# Altium schematic sheet CAN_Module.SchDoc
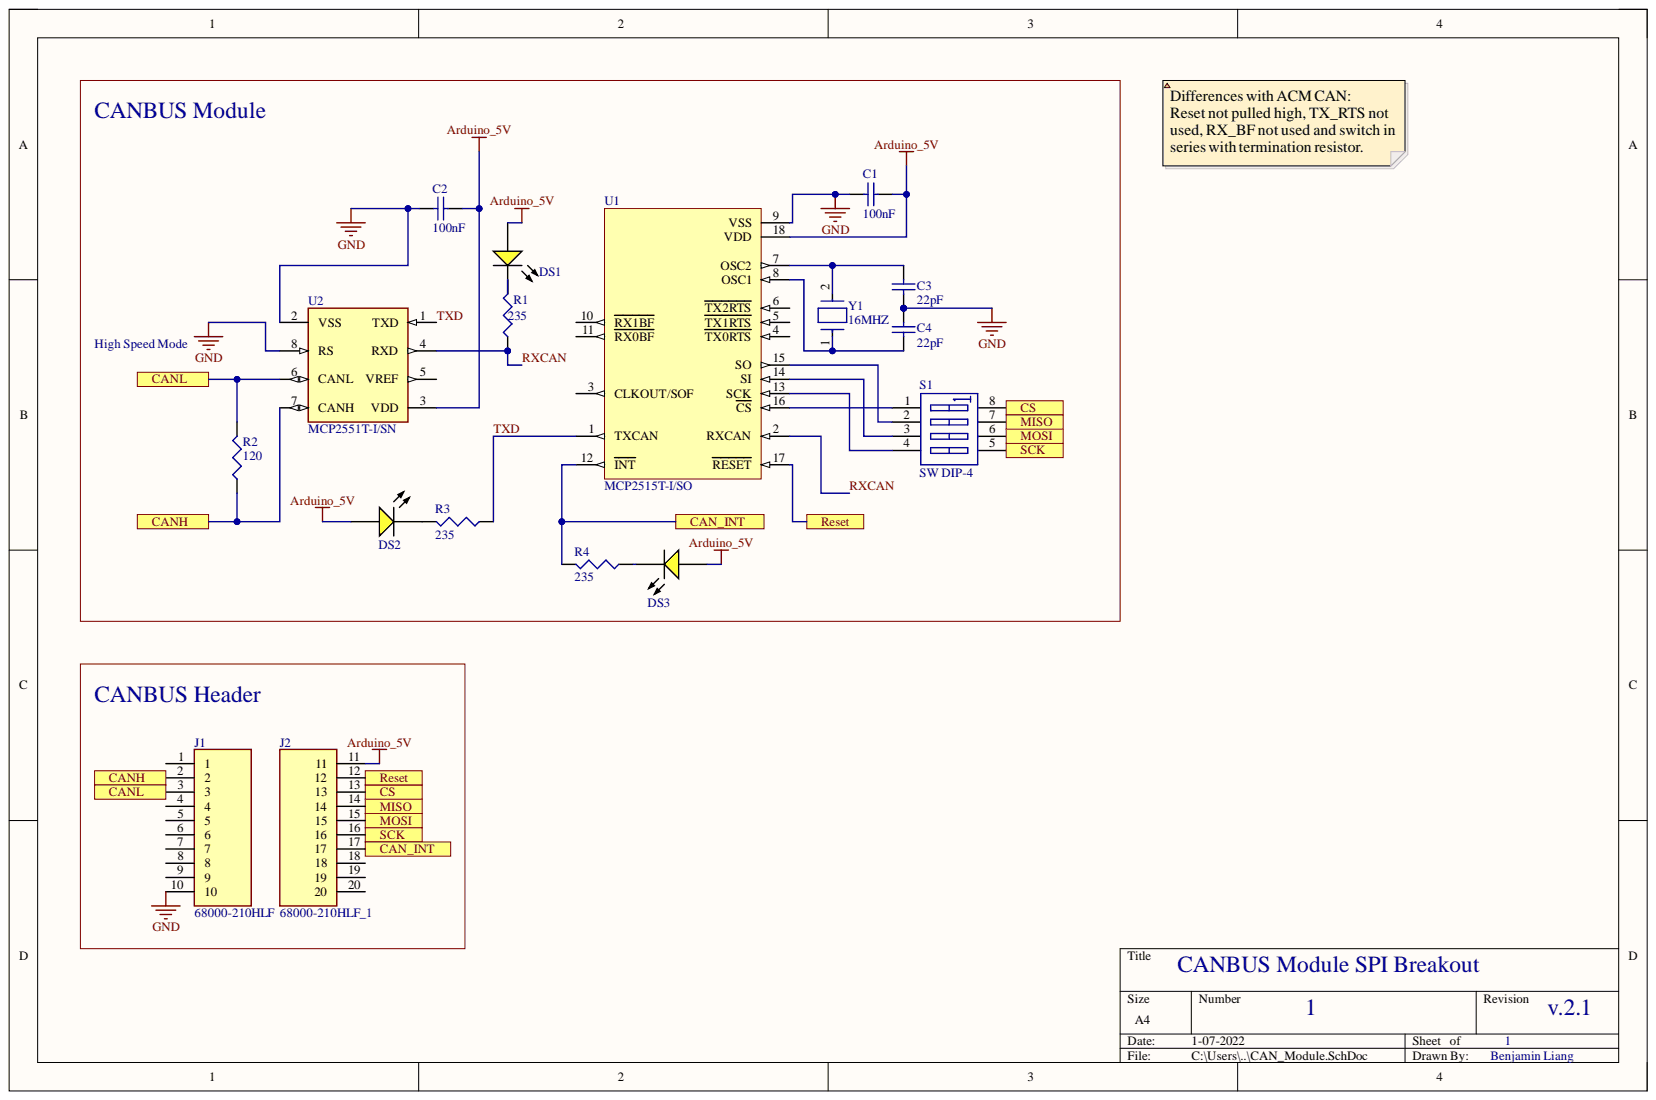
[diagram p0: CAN_Module.SchDoc]

## Parts
C1  100nF  [C1206]
C2  100nF  [C1206]
C3  22pF  [C1206]
C4  22pF  [C1206]
DS1  HLMP-1719  [AVAG-HLMP-1719_V]  (value not drawn)
DS2  HLMP-1719  [AVAG-HLMP-1719_V]  (value not drawn)
DS3  HLMP-1719  [AVAG-HLMP-1719_V]  (value not drawn)
J1  68000-210HLF  [HDRV10W63P0X254_1X10_2540X241X858P]
J2  68000-210HLF_1  Arrow Part Number: 68000-210HLF  [HDRV10W63P0X254_1X10_2540X241X858P]
R1  235  [J1-0603]
R2  120  [J1-0603]
R3  235  [J1-0603]
R4  235  [J1-0603]
S1  SW DIP-4  [SO8_N]
U1  MCP2515T-I/SO  [SOIC-SO18_N]
U2  MCP2551T-I/SN  [FP-C04-057-SN-IPC_B]
Y1  16MHZ  [FP-ABLS-MFG]

## Ports
CAN_INT, CANH, CANL, CS, MISO, MOSI, Reset, SCK

## Nets
Arduino_5V: C1.1 C2.1 DS1.1 DS2.1 DS3.1 J2.11 U1.18(VDD) U2.3(VDD)
GND: C1.2 C2.2 C3.1 C4.2 J1.10 U1.9(VSS) U2.2(VSS) U2.8(RS)
CAN_INT: J2.17 R4.2 U1.12(INT) ; port CAN_INT
CANH: J1.2 R2.1 U2.7(CANH) ; port CANH
CANL: J1.3 R2.2 U2.6(CANL) ; port CANL
CS: J2.13 S1.8 ; port CS
MISO: J2.14 S1.7 ; port MISO
MOSI: J2.15 S1.6 ; port MOSI
Reset: J2.12 U1.17(RESET) ; port Reset
RXCAN: R1.1 U1.2(RXCAN) U2.4(RXD)
SCK: J2.16 S1.5 ; port SCK
TXD: R3.1 U1.1(TXCAN) U2.1(TXD)
NetC3_2: C3.2 U1.7(OSC2) Y1.2
NetC4_1: C4.1 U1.8(OSC1) Y1.1
NetDS1_2: DS1.2 R1.2
NetDS2_2: DS2.2 R3.2
NetDS3_2: DS3.2 R4.1
NetS1_1: S1.1 U1.16(CS)
NetS1_2: S1.2 U1.15(SO)
NetS1_3: S1.3 U1.14(SI)
NetS1_4: S1.4 U1.13(SCK)

Not connected: J1 1 4 5 6 7 8 9; J2 18 19 20; U1 3(CLKOUT/SOF) 4(TX0RTS) 5(TX1RTS) 6(TX2RTS) 10(RX1BF) 11(RX0BF); U2 5(VREF)

## Library data of the parts
C1-C4 = Cap Semi: Capacitor (Semiconductor SIM Model); Component Kind: Standard; LatestRevisionDate: 29-May-2009; LatestRevisionNote: IPC-7351 Footprint Added.; Library Name: Miscellaneous Devices.IntLib; PackageDescription: Chip Capacitor; PackageReference: C1206; Published: 8-Jun-2000; Signal Integrity: Cap Semi; Simulation: CAP; Publisher: Altium Limited
  C1 C2: Value: 100nF
  C3 C4: Value: 22pF
DS1-DS3 = CMP-2000-06410-1: Low Current LED Lamp, T-1 (3 mm), 1.8 V, 2 mA, -40 to 100 degC, 2-Pin THD, RoHS, Bulk; Manufacturer: AvagoTechnologies; Color: Yellow; Dominant Wavelength/Color Temperature: 585nm; Intensity: 2.1mcd; Lens Appearance: ColoredDiffused; Lens Shape Type: Circular; Mounting Orientation: TopMount; Number of LEDs: 1; Type: Uni-Color; Viewing Angle: 50°; Category: LEDs; Component Kind: Standard; Datasheet URL: http://www.avagotech.com/docs/AV02-1557EN; Datasheet Version: 07/2014; Library Name: Altium Content Vault; Manufacturer URL: http://www.avagotech.com/; Mounting: ThroughHole; Mounting Technology: ThroughHole; Package: 2T-1; Package Description: 2-Pin Through Hole Device, Body Dia 3.175 mm; Package Reference: HLMP-1719; Packaging: Bulk; Pin Count: 2
J1 = 68000-210HLF: Connector; Manufacturer_Name: Amphenol; Arrow Part Number: 68000-210HLF; Arrow Price/Stock: https://www.arrow.com/en/products/68000-210hlf/amphenol-fci; Component Kind: Standard; Datasheet Link: https://cdn.amphenol-icc.com/media/wysiwyg/files/drawing/68000.pdf; Height: 8.58mm; Manufacturer_Part_Number: 68000-210HLF; Mouser Part Number: 649-68000-210HLF; Mouser Price/Stock: https://www.mouser.co.uk/ProductDetail/Amphenol-FCI/68000-210HLF?qs=QKvFUfBIyQINb7f%2F4SDc2A%3D%3D
J2 = 68000-210HLF_1: Connector; Manufacturer_Name: Amphenol; Arrow Price/Stock: https://www.arrow.com/en/products/68000-210hlf/amphenol-fci; Component Kind: Standard; Datasheet Link: https://cdn.amphenol-icc.com/media/wysiwyg/files/drawing/68000.pdf; Height: 8.58mm; Library Name: SamacSys.SchLib; Manufacturer_Part_Number: 68000-210HLF; Mouser Part Number: 649-68000-210HLF; Mouser Price/Stock: https://www.mouser.co.uk/ProductDetail/Amphenol-FCI/68000-210HLF?qs=QKvFUfBIyQINb7f%2F4SDc2A%3D%3D
R1-R4 = Res3: Resistor; Value: 220; Component Kind: Standard; LatestRevisionDate: 29-May-2009; LatestRevisionNote: IPC-7351 Footprint Added.; Library Name: Miscellaneous Devices.IntLib; PackageDescription: Chip Resistor; PackageReference: J1-0603; Published: 8-Jun-2000; Signal Integrity: Res; Simulation: RESISTOR; Publisher: Altium Limited
S1 = SW DIP-4: DIP Switch, 4 Position, SPST; Code_IPC: SOIC127P600-8; Code_JEDEC: MS-012-AA; Component Kind: Standard; LatestRevisionDate: 29-May-2009; LatestRevisionNote: IPC-7351 Footprint Added.; Library Name: Miscellaneous Devices.IntLib; PackageDescription: 8-Pin Small Outline Integrated Circuit 1.27 mm Pitch; PackageReference: SO8; PackageVersion: Dec-2002; Published: 24-Mar-1999; Simulation: dpsw4; Publisher: Altium Limited
U1 = CMP-0186-00139-2: Stand-Alone CAN Controller With SPI Interface, 18-Pin SOIC, Industrial Temperature, Tape and Reel; Code_JEDEC: MS-013; Component Kind: Standard; ComponentLink1Description: Manufacturer URL; ComponentLink1URL: http://www.microchip.com/; ComponentLink2Description: Datasheet; ComponentLink2URL: http://ww1.microchip.com/downloads/en/DeviceDoc/21801f.pdf; ComponentLink3Description: Package Specification; ComponentLink3URL: http://www.microchip.com/stellent/groups/techpub_sg/documents/packagingspec/en012702.pdf; DatasheetVersion: revF, Oct-2010; Library Name: Altium Content Vault; PackageDescription: 18-Lead Plastic Small Outline (SO) - Wide 7.50mm Body [SOIC]; PackageReference: SOIC-SO18; PackageVersion: revBB, Aug-2009; RevisionNote: URLs added. DatasheetVersion updated.
U2 = CMP-0186-00037-3: IC TRANSCEIVER HALF 1/1 8SOIC; Data Rate: 1Mbps; Interface: CAN; Max Supply Current: 75mA; Max Supply Voltage: 5.5V; Min Supply Voltage: 4.5V; Number of Drivers: 1; Operating Supply Voltage: 5V; Case/Package: SOIC; Component Kind: Standard; Height: 1.5mm; Lead Free: Lead Free; Length: 4.9mm; Library Name: Altium Content Vault; Logic Function: Transceiver; Max Operating Temperature: 85°C; Min Operating Temperature: -40°C; Mount: Surface Mount; Nominal Supply Current: 75mA; Number of Pins: 8; Number of Receive Buffers: 1; Number of Receivers: 1; Number of Transceivers: 1; Number of Transmit Buffers: 1; Package Quantity: 3300; Packaging: Tape and Reel; Radiation Hardening: No; REACH SVHC: No SVHC; Width: 3.9mm
Y1 = CMP-27762-000003-1: CRYSTAL 16.0000MHZ 18PF SMD  ABLS-16.000MHZ-K4T; Manufacturer: Abracon; Esr: 40Ω; Stability: 50ppm; Standoff Height: 0mm; Tolerance: 30ppm; Automotive: No; Category: Crys; Component Kind: Standard; ComponentLink2Description: Datasheet; ComponentLink2URL: https://abracon.com/Resonators/abls.pdf; Device Class L1: Passive Components; Device Class L2: Crystals / Oscillators; Device Class L3: Crystals; Digikey Description: CRYSTAL 16.0000MHZ 18PF SMD; Frequency: 16MHz; Height: 4.3mm; Lead Free: Yes; Library Name: Altium Content Vault; Load Capacitance: 18pF; Manufacturer Part Number: ABLS-16.000MHZ-K4T; Mouser Description: Crystal 16MHz ±30ppm (Tol) ±50ppm (Stability) 18pF FUND 40Ohm 2-Pin HC-49/US SMD T/R; Octopart: https://octopart.com/abls-16.000mhz-k4t-abracon-18618626; Package: ABLS; RoHS: TRUE; Series: ABLS; Temperature: -40°C to +125°C; Temperature Range High: +125°C; Temperature Range Low: -40°C
